# Altium schematic sheet PCIe-SATA.SchDoc(PCIe-SATA)
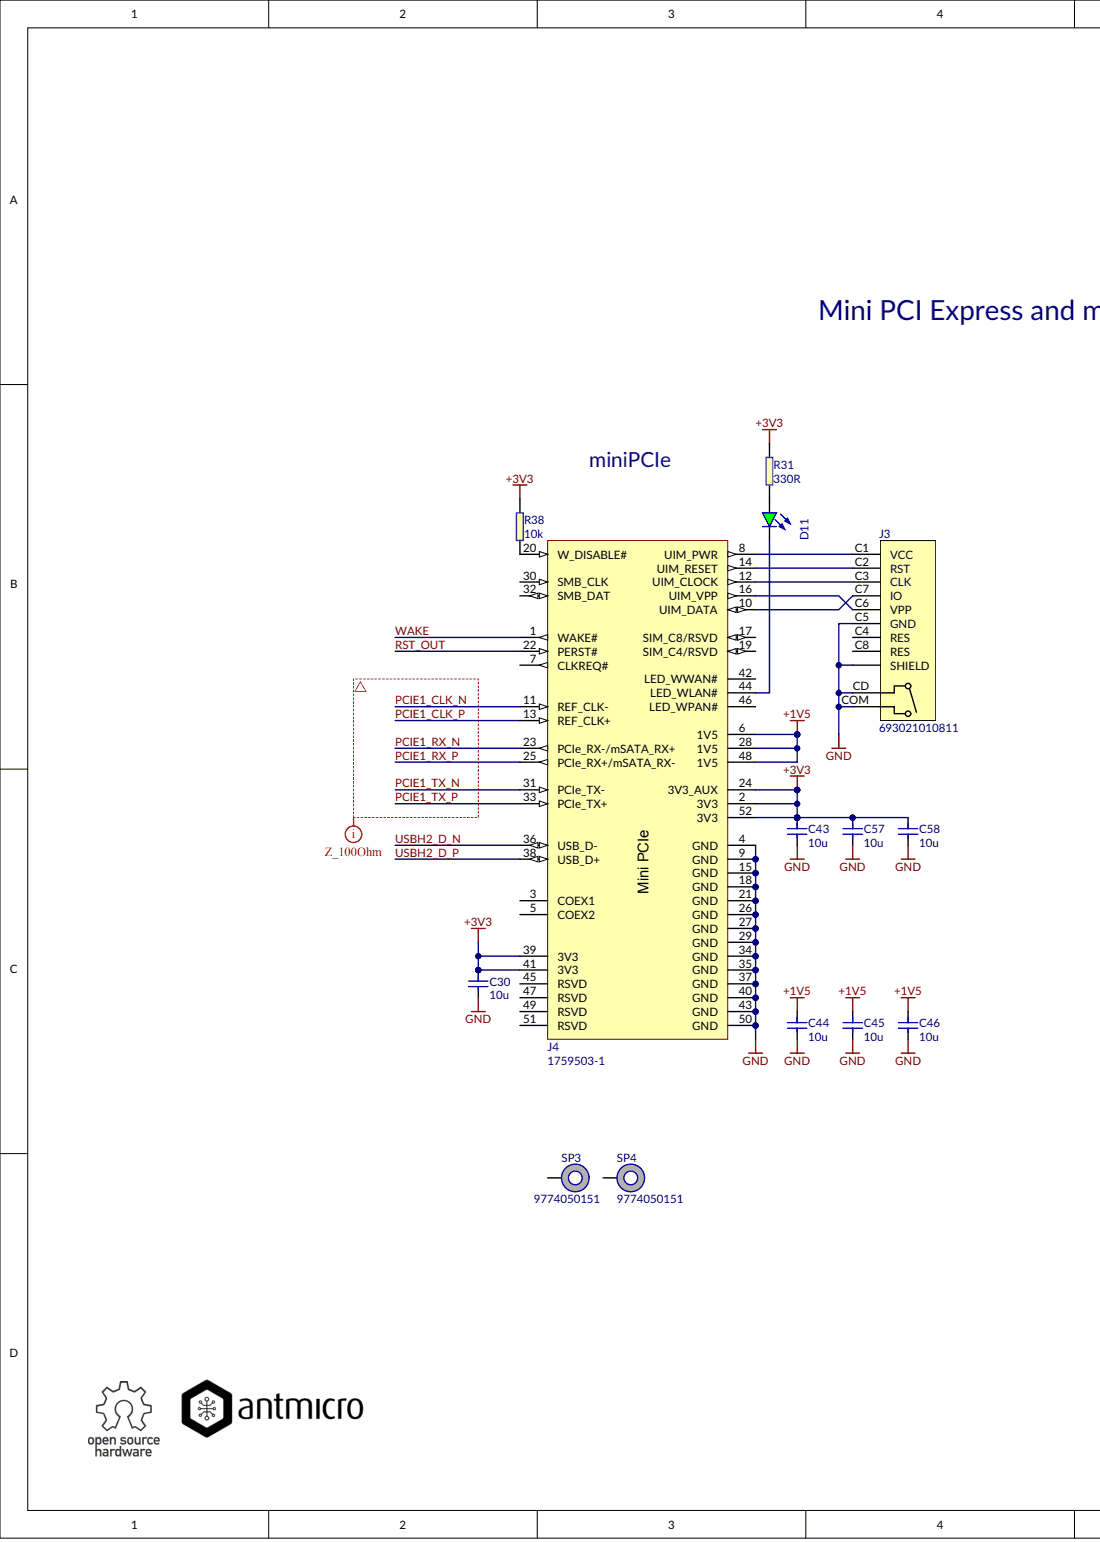
[diagram p0: PCIe-SATA.SchDoc, left]
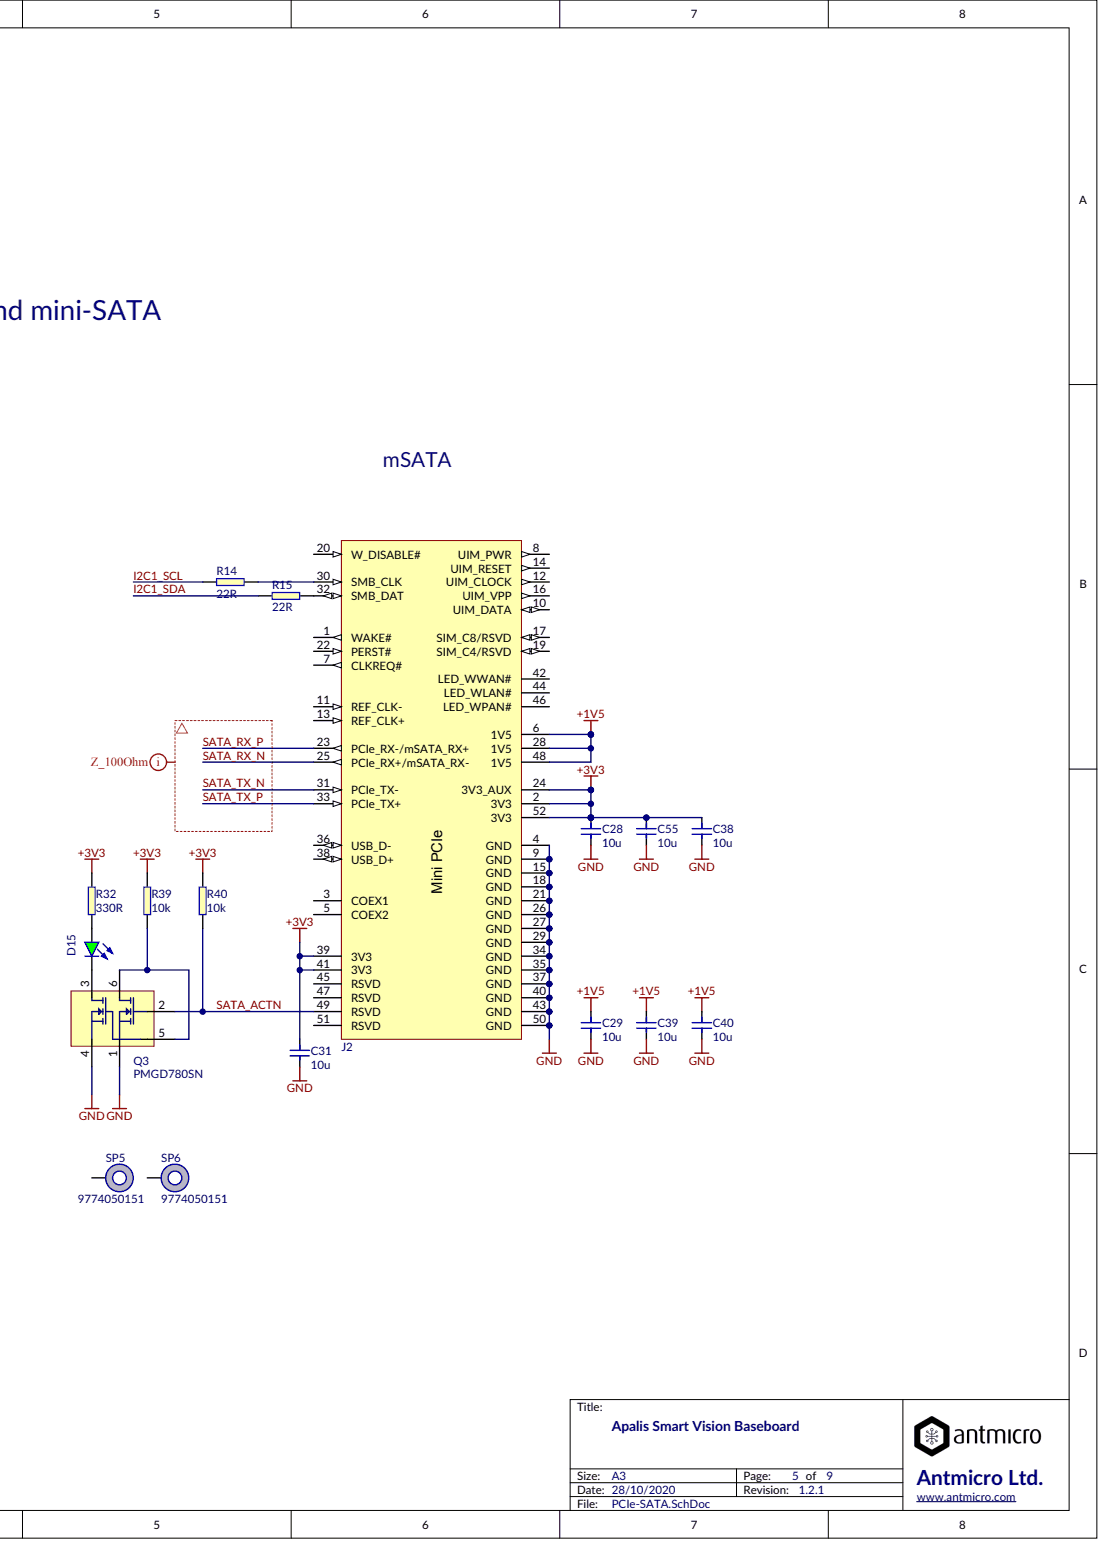
[diagram p1: PCIe-SATA.SchDoc, right]

## Parts
C28  10u
C29  10u
C30  10u
C31  10u
C38  10u
C39  10u
C40  10u
C43  10u
C44  10u
C45  10u
C46  10u
C55  10u
C57  10u
C58  10u
D11  KPT-1608SGC  (value not drawn)
D15  KPT-1608SGC  (value not drawn)
J2  1759503-1  (value not drawn)
J3  693021010811
J4  1759503-1
Q3  PMGD780SN
R14  22R
R15  22R
R31  330R
R32  330R
R38  10k
R39  10k
R40  10k
SP3  9774050151
SP4  9774050151
SP5  9774050151
SP6  9774050151

## Nets
+1V5: C29.1 C39.1 C40.1 C44.1 C45.1 C46.1 J2.6(1V5) J2.28(1V5) J2.48(1V5) J4.6(1V5) J4.28(1V5) J4.48(1V5)
+3V3: C28.1 C30.1 C31.1 C38.1 C43.1 C55.1 C57.1 C58.1 J2.2(3V3) J2.24(3V3_AUX) J2.39(3V3) J2.41(3V3) J2.52(3V3) J4.2(3V3) J4.24(3V3_AUX) J4.39(3V3) J4.41(3V3) J4.52(3V3) R31.2 R32.2 R38.2 R39.2 R40.2
GND: C28.2 C29.2 C30.2 C31.2 C38.2 C39.2 C40.2 C43.2 C44.2 C45.2 C46.2 C55.2 C57.2 C58.2 J2.4(GND) J2.9(GND) J2.15(GND) J2.18(GND) J2.21(GND) J2.26(GND) J2.27(GND) J2.29(GND) J2.34(GND) J2.35(GND) J2.37(GND) J2.40(GND) J2.43(GND) J2.50(GND) J3.C5(GND) J3.CD J3.COM J3.SHIELD J4.4(GND) J4.9(GND) J4.15(GND) J4.18(GND) J4.21(GND) J4.26(GND) J4.27(GND) J4.29(GND) J4.34(GND) J4.35(GND) J4.37(GND) J4.40(GND) J4.43(GND) J4.50(GND) Q3.1 Q3.4
I2C1_SCL: R14.1
I2C1_SDA: R15.1
PCIE1_CLK_N: J4.11(REF_CLK-)
PCIE1_CLK_P: J4.13(REF_CLK+)
PCIE1_RX_N: J4.23(PCIe_RX-/mSATA_RX+)
PCIE1_RX_P: J4.25(PCIe_RX+/mSATA_RX-)
PCIE1_TX_N: J4.31(PCIe_TX-)
PCIE1_TX_P: J4.33(PCIe_TX+)
RST_OUT: J4.22(PERST#)
SATA_ACTN: J2.49(RSVD) Q3.2 R40.1
SATA_RX_N: J2.25(PCIe_RX+/mSATA_RX-)
SATA_RX_P: J2.23(PCIe_RX-/mSATA_RX+)
SATA_TX_N: J2.31(PCIe_TX-)
SATA_TX_P: J2.33(PCIe_TX+)
USBH2_D_N: J4.36(USB_D-)
USBH2_D_P: J4.38(USB_D+)
WAKE: J4.1(WAKE#)
NetD11_A: D11.A R31.1
NetD11_K: D11.K J4.44(LED_WLAN#)
NetD15_A: D15.A R32.1
NetD15_K: D15.K Q3.3
NetJ2_30: J2.30(SMB_CLK) R14.2
NetJ2_32: J2.32(SMB_DAT) R15.2
NetJ3_C1: J3.C1(VCC) J4.8(UIM_PWR)
NetJ3_C2: J3.C2(RST) J4.14(UIM_RESET)
NetJ3_C3: J3.C3(CLK) J4.12(UIM_CLOCK)
NetJ3_C6: J3.C6(VPP) J4.16(UIM_VPP)
NetJ3_C7: J3.C7(IO) J4.10(UIM_DATA)
NetJ4_20: J4.20(W_DISABLE#) R38.1
NetQ3_5: Q3.5 Q3.6 R39.1
N00219:
N00220:

Not connected: J2 1(WAKE#) 3(COEX1) 5(COEX2) 7(CLKREQ#) 8(UIM_PWR) 10(UIM_DATA) 11(REF_CLK-) 12(UIM_CLOCK) 13(REF_CLK+) 14(UIM_RESET) 16(UIM_VPP) 17(SIM_C8/RSVD) 19(SIM_C4/RSVD) 20(W_DISABLE#) 22(PERST#) 36(USB_D-) 38(USB_D+) 42(LED_WWAN#) 44(LED_WLAN#) 45(RSVD) 46(LED_WPAN#) 47(RSVD) 51(RSVD); J3 C4(RES) C8(RES); J4 3(COEX1) 5(COEX2) 7(CLKREQ#) 17(SIM_C8/RSVD) 19(SIM_C4/RSVD) 30(SMB_CLK) 32(SMB_DAT) 42(LED_WWAN#) 45(RSVD) 46(LED_WPAN#) 47(RSVD) 49(RSVD) 51(RSVD); SP3 1; SP4 1; SP5 1; SP6 1

## Library data of the parts
C28-C31 C38-C40 C43-C46 C55 C57 C58 = (no symbol name): Manufacturer: Walsin; Part Number: C_10u_0603; Part Symbol: 0603X106M6R3CT; Value: 10u; MPN: 0603X106M6R3CT
D11 D15 = (no symbol name): Part Number: LED_G; Manufacturer: KINGBRIGHT; Value: KPT-1608SGC; Part Symbol: KPT-1608SGC; MPN: KPT-1608SGC
J2 J4 = (no symbol name): Part Number: TE-1759503-1; Manufacturer: TE CONNECTIVITY; Value: 1759503-1; Part Symbol: 1759503-1; MPN: 1759503-1
J3 = (no symbol name): Manufacturer: WURTH ELEKTRONIK; Part Number: WE-693-021-010-811; Value: 693021010811; Part Symbol: 693021010811; MPN: 693021010811
Q3 = (no symbol name): Manufacturer: NXP; Part Symbol: PMGD780SN,115; Part Number: PMGD780SN; Value: PMGD780SN; MPN: PMGD780SN,115
R14 R15 = (no symbol name): Manufacturer: VISHAY; Part Number: R_22R_0402; Part Symbol: CRCW040222R0FKED; Value: 22R; MPN: CRCW040222R0FKED
R31 R32 = (no symbol name): Manufacturer: MULTICOMP; Part Number: R_330R_0402; Part Symbol: MCMR04X3300FTL; Value: 330R; MPN: MCMR04X3300FTL
R38-R40 = (no symbol name): Manufacturer: MULTICOMP; Part Number: R_10k_0402; Part Symbol: MCMR04X1002FTL; Value: 10k; MPN: MCMR04X1002FTL
SP3-SP6 = (no symbol name): Manufacturer: WURTH ELEKTRONIK; Part Number: 9774050151; Value: 9774050151; Part Symbol: 9774050151; MPN: 9774050151
